# Altium schematic sheet Analog_Frontend02.SchDoc
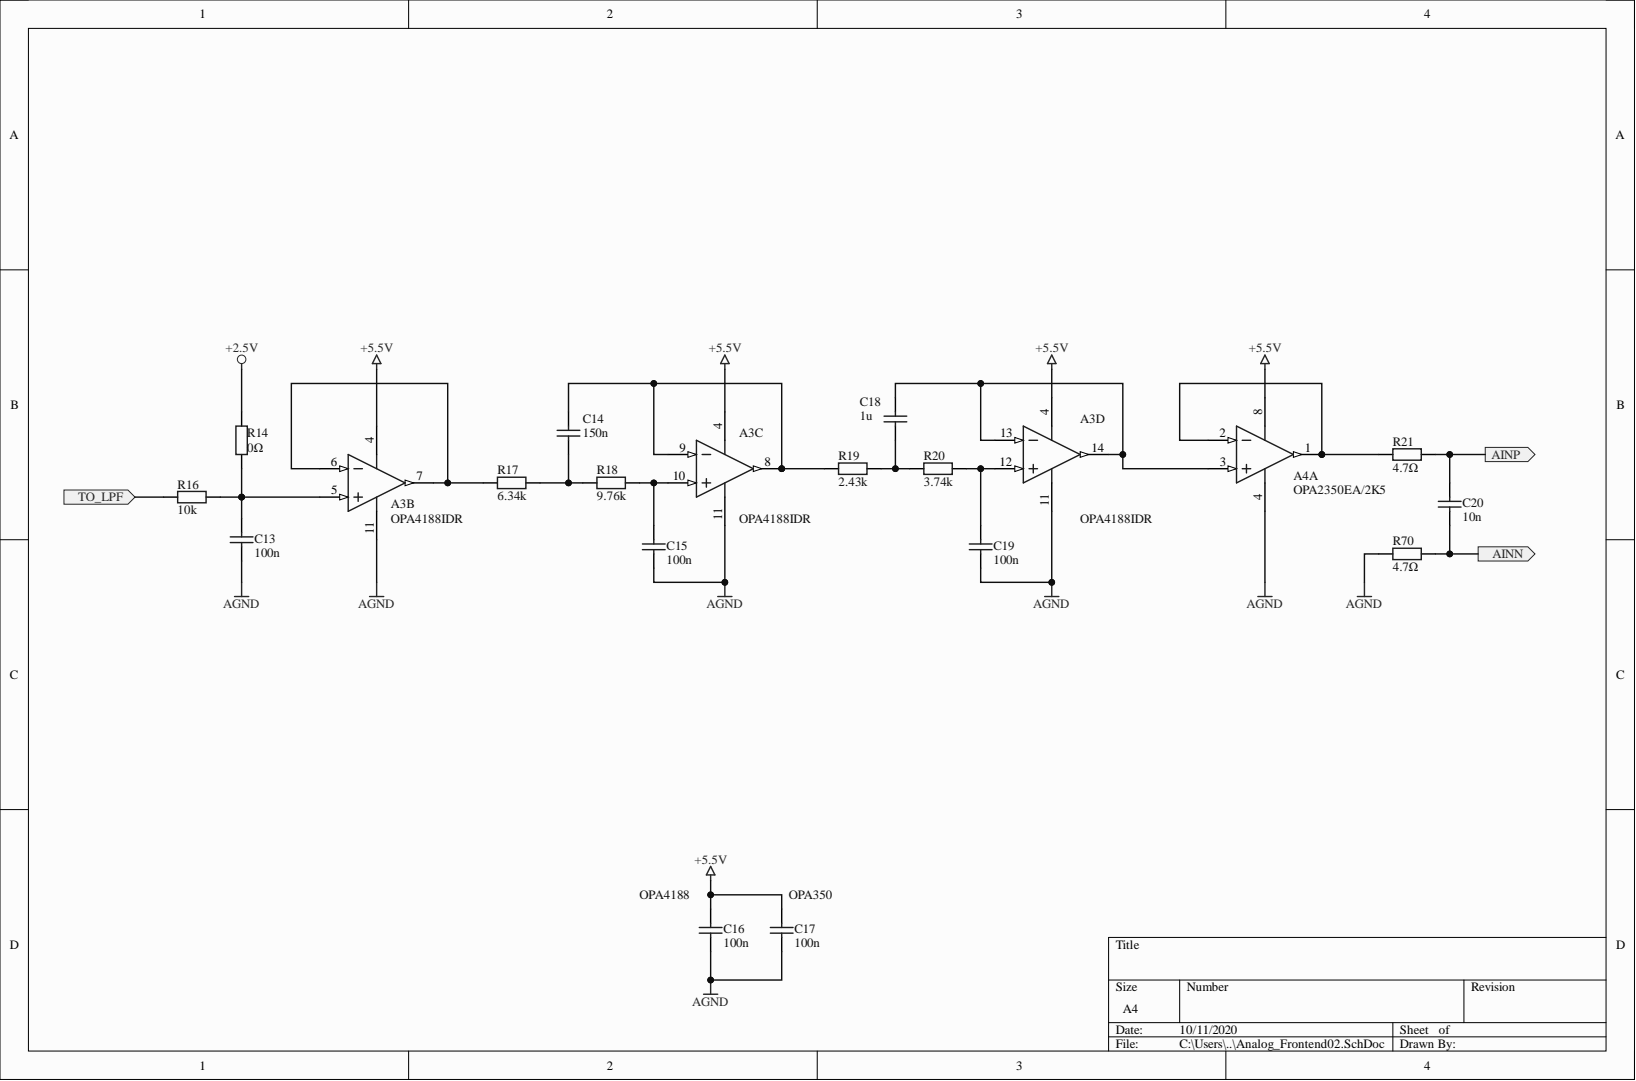
[diagram p0: Analog_Frontend02.SchDoc]

## Parts
A3  OPA4188IDR  [SOIC127P600X175-14N]  (parts B,C,D)
A4  OPA2350EA/2K5  [SOIC127P600X175-8N]  (part A)
C13  100n  [CAPC1608X87N]
C14  150n  [CAPC1608X87N]
C15  100n  [CAPC1608X87N]
C16  100n  [CAPC1608X87N]
C17  100n  [CAPC1608X87N]
C18  1u
C19  100n  [CAPC1608X87N]
C20  10n  [CAPC1608X87N]
R14  0Ω  [RESC1608X55N]
R16  10k  [RESC1608X55N]
R17  6.34k  [RESC1608X55N]
R18  9.76k  [RESC1608X55N]
R19  2.43k  [RESC1608X55N]
R20  3.74k  [RESC1608X55N]
R21  4.7Ω  [RESC1608X55N]
R70  4.7Ω  [RESC1608X55N]

## Ports
AINN, AINP, TO_LPF

## Nets
+2.5V: R14.2
+5.5V: A3.4 A4.8 C16.2 C17.2
AGND: A3.11 A4.4 C13.1 C15.1 C16.1 C17.1 C19.1 R70.1
AINN: C20.1 R70.2 ; port AINN
AINP: C20.2 R21.2 ; port AINP
TO_LPF: R16.1 ; port TO_LPF
NetA3_5: A3.5 C13.2 R14.1 R16.2
NetA3_6: A3.6 A3.7 R17.1
NetA3_8: A3.8 A3.9 C14.2 R19.1
NetA3_10: A3.10 C15.2 R18.2
NetA3_12: A3.12 C19.2 R20.2
NetA3_13: A3.13 A3.14 A4.3 C18.2
NetA4_1: A4.1 A4.2 R21.1
NetC14_1: C14.1 R17.2 R18.1
NetC18_1: C18.1 R19.2 R20.1

## Library data of the parts
A3 = AD824ARZ-14-3V-RL: Manufacturer 1: Texas Instruments; Component Kind: Standard; Library Name: Analog Devices Operational Amplifiers.IntLib; Manufacturer Part Number 1: OPA4188IDR
A4 = OPA2350EA/250: Manufacturer 1: Texas Instruments; Component Kind: Standard; Library Name: TI Amplifiers and Linear Operational Amplifier Precision Amplifier Part One.IntLib; Manufacturer Part Number 1: OPA2350EA/2K5
C13 C15-C17 C19 = Cap: Manufacturer 1: Taiyo Yuden; Manufacturer Part Number 1: EMK107B7104KA-T; Component Kind: Standard; Library Name: Miscellaneous Devices.IntLib; Signal Integrity: Cap; Simulation: CAP
C14 = Cap: Manufacturer 1: Samsung Electro-Mechanics; Manufacturer Part Number 1: CL10B154KO8NNNC; Component Kind: Standard; Library Name: Miscellaneous Devices.IntLib; Signal Integrity: Cap; Simulation: CAP
C18 = Cap: Manufacturer 1: Taiyo Yuden; Manufacturer Part Number 1: EMK107BJ105KA-T; Component Kind: Standard; Library Name: Miscellaneous Devices.IntLib; Signal Integrity: Cap; Simulation: CAP
C20 = Cap: Manufacturer 1: KEMET; Manufacturer Part Number 1: C0603C103J4RACAUTO; Component Kind: Standard; Library Name: Miscellaneous Devices.IntLib; Signal Integrity: Cap; Simulation: CAP
R14 = Res2: Manufacturer 1: Panasonic; Manufacturer Part Number 1: ERJ-3GEY0R00V; Component Kind: Standard; Library Name: Miscellaneous Devices.IntLib; Signal Integrity: Res; Simulation: RESISTOR
R16 = Res2: Manufacturer 1: Yageo; Manufacturer Part Number 1: RC0603FR-0710KL; Component Kind: Standard; Library Name: Miscellaneous Devices.IntLib; MOUSER Part Number: 603-RC0603FR-0710KL; Signal Integrity: Res; Simulation: RESISTOR
R17 = Res2: Manufacturer 1: Vishay/Dale; Manufacturer Part Number 1: CRCW06036K34FKEA; Component Kind: Standard; Library Name: Miscellaneous Devices.IntLib; Signal Integrity: Res; Simulation: RESISTOR
R18 = Res2: Manufacturer 1: Vishay/Dale; Manufacturer Part Number 1: CRCW06039K76FKEA; Component Kind: Standard; Library Name: Miscellaneous Devices.IntLib; Signal Integrity: Res; Simulation: RESISTOR
R19 = Res2: Manufacturer 1: Vishay/Dale; Manufacturer Part Number 1: CRCW06032K43FKEA; Component Kind: Standard; Library Name: Miscellaneous Devices.IntLib; Signal Integrity: Res; Simulation: RESISTOR
R20 = Res2: Manufacturer 1: Vishay/Dale; Manufacturer Part Number 1: CRCW06033K74FKEA; Component Kind: Standard; Library Name: Miscellaneous Devices.IntLib; Signal Integrity: Res; Simulation: RESISTOR
R21 R70 = Res2: Manufacturer 1: Vishay/Dale; Manufacturer Part Number 1: CRCW06034R70FKEAC; Component Kind: Standard; Library Name: Miscellaneous Devices.IntLib; Signal Integrity: Res; Simulation: RESISTOR
